# Altium schematic sheet Primary MCU.SchDoc
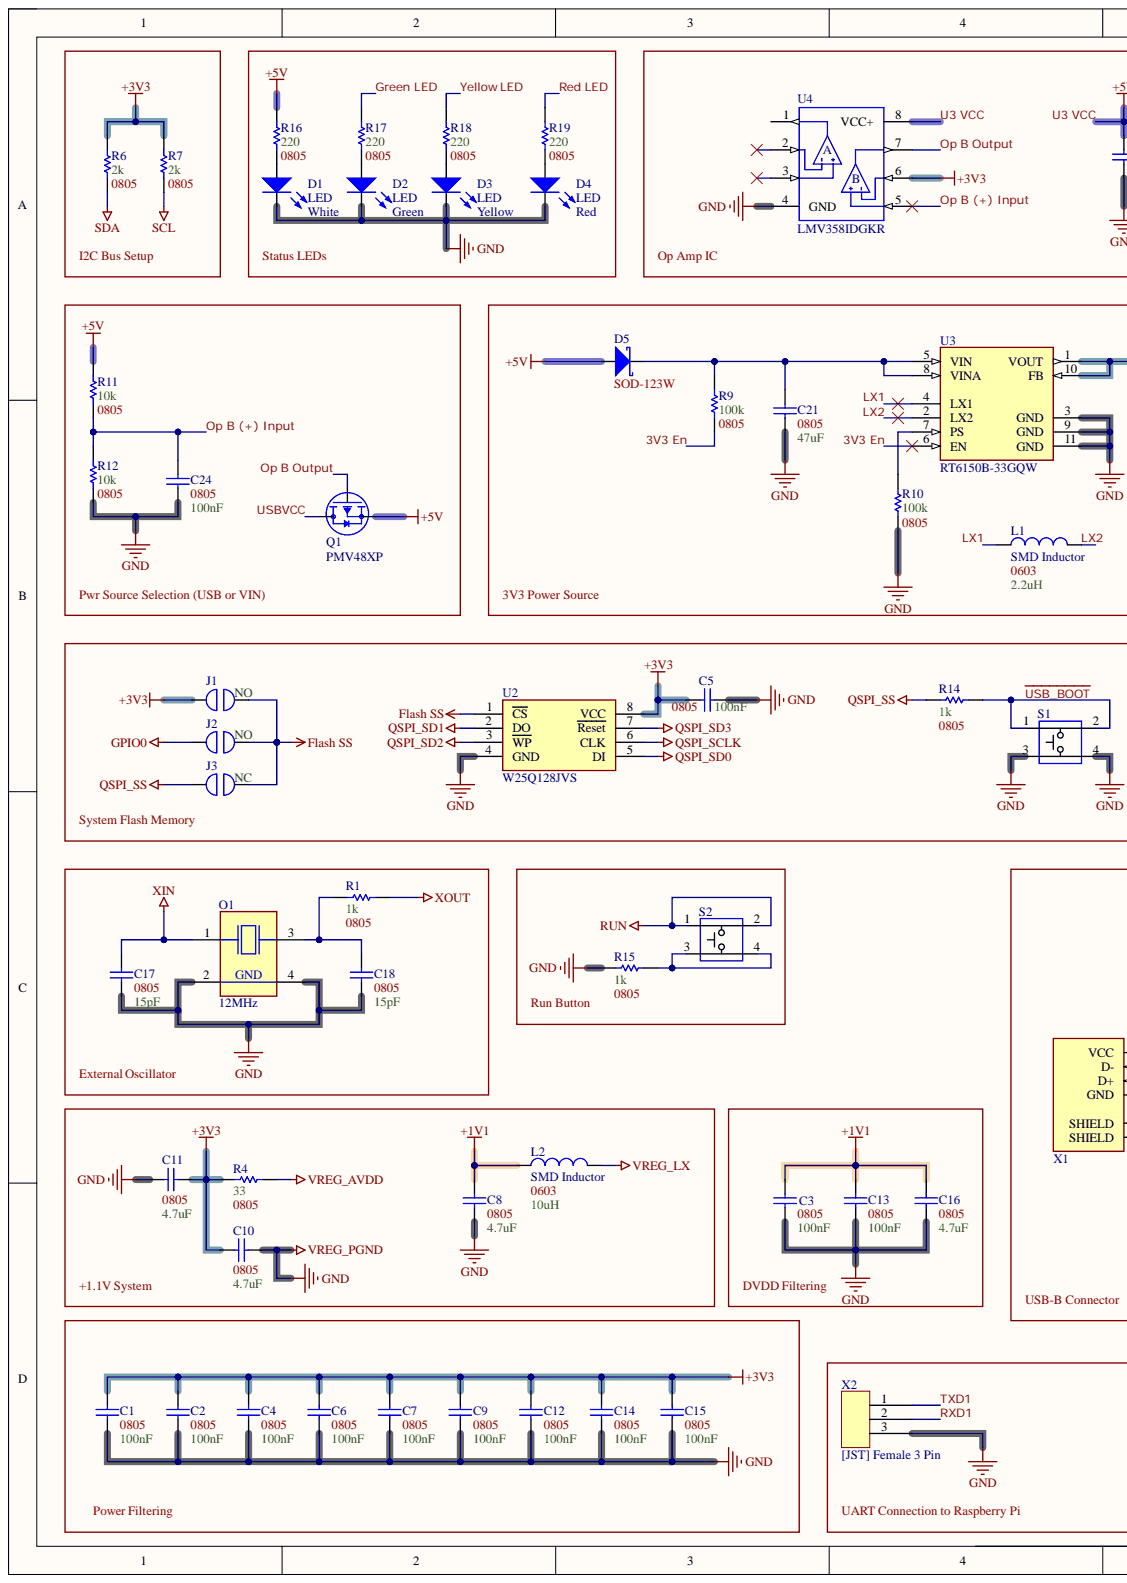
[diagram p0: Primary MCU.SchDoc, left]
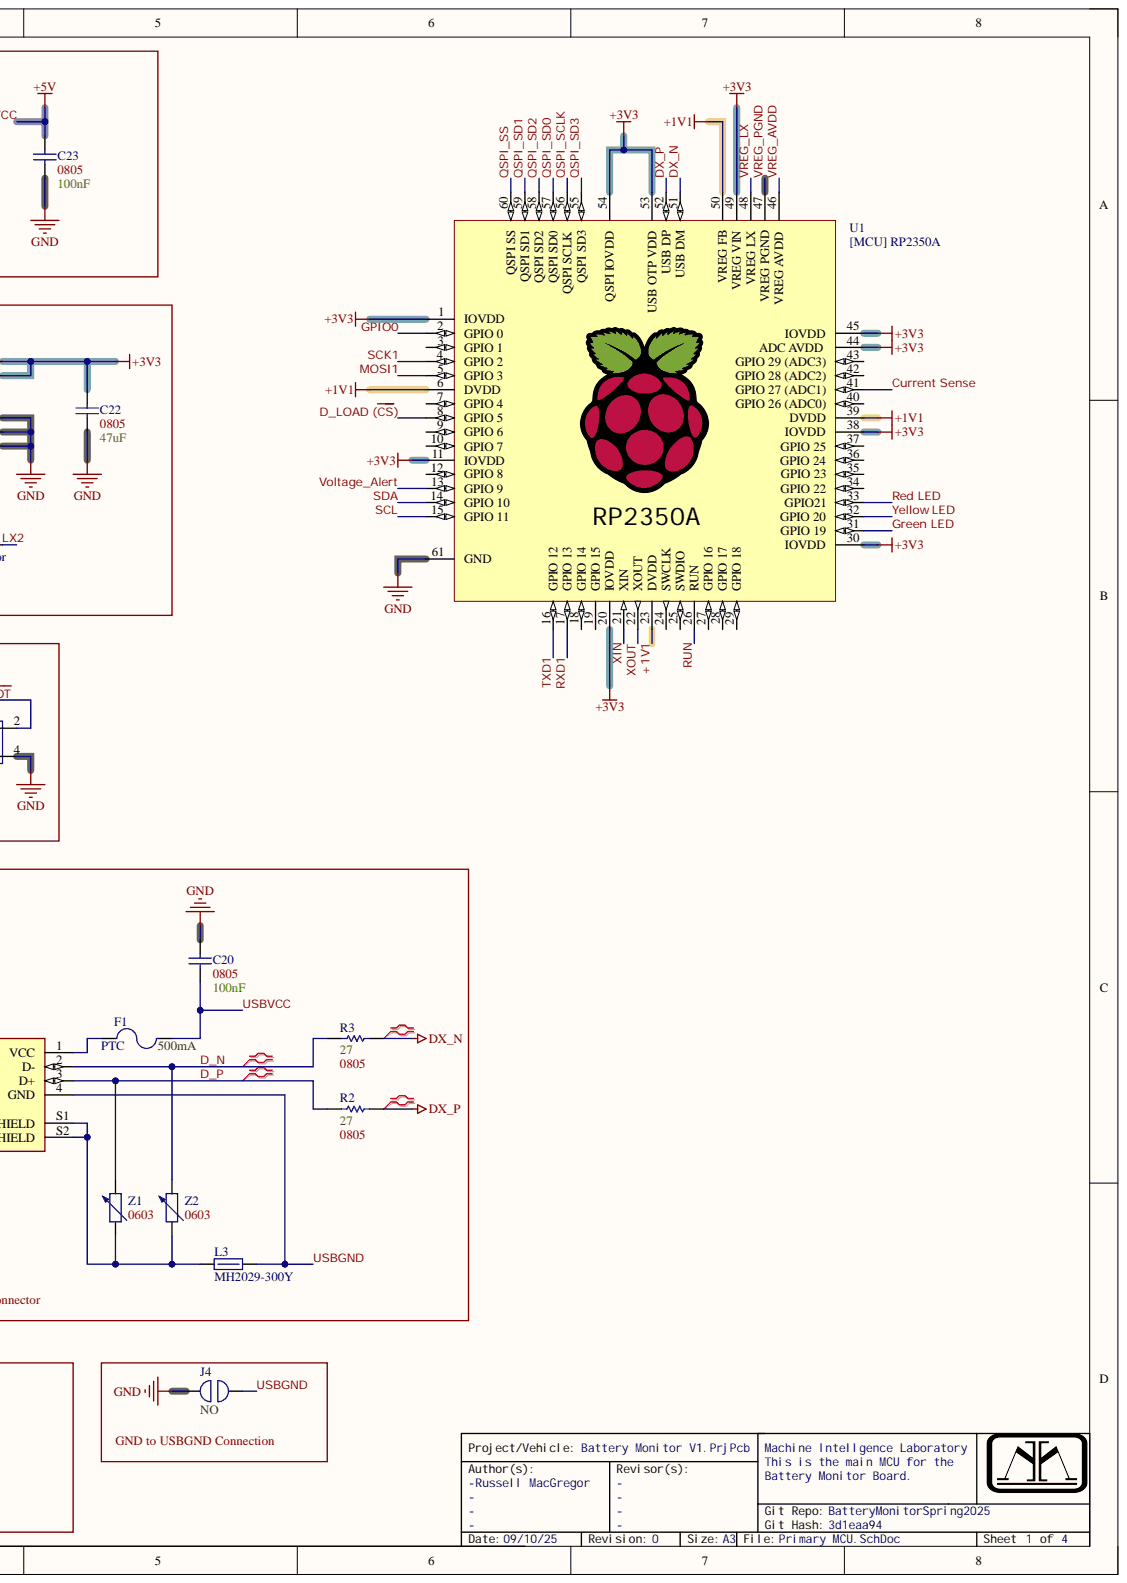
[diagram p1: Primary MCU.SchDoc, right]

## Parts
C1  Capacitance: 100nF  Size Package: 0805  [[Cap] SMD 0805]  (hidden comment: [Cap] SMD Nonpolarized)
C2  Capacitance: 100nF  Size Package: 0805  [[Cap] SMD 0805]  (hidden comment: [Cap] SMD Nonpolarized)
C3  Capacitance: 100nF  Size Package: 0805  [[Cap] SMD 0805]  (hidden comment: [Cap] SMD Nonpolarized)
C4  Capacitance: 100nF  Size Package: 0805  [[Cap] SMD 0805]  (hidden comment: [Cap] SMD Nonpolarized)
C5  Capacitance: 100nF  Size Package: 0805  [[Cap] SMD 0805]  (hidden comment: [Cap] SMD Nonpolarized)
C6  Capacitance: 100nF  Size Package: 0805  [[Cap] SMD 0805]  (hidden comment: [Cap] SMD Nonpolarized)
C7  Capacitance: 100nF  Size Package: 0805  [[Cap] SMD 0805]  (hidden comment: [Cap] SMD Nonpolarized)
C8  Capacitance: 4.7uF  Size Package: 0805  [[Cap] SMD 0805]  (hidden comment: [Cap] SMD Nonpolarized)
C9  Capacitance: 100nF  Size Package: 0805  [[Cap] SMD 0805]  (hidden comment: [Cap] SMD Nonpolarized)
C10  Capacitance: 4.7uF  Size Package: 0805  [[Cap] SMD 0805]  (hidden comment: [Cap] SMD Nonpolarized)
C11  Capacitance: 4.7uF  Size Package: 0805  [[Cap] SMD 0805]  (hidden comment: [Cap] SMD Nonpolarized)
C12  Capacitance: 100nF  Size Package: 0805  [[Cap] SMD 0805]  (hidden comment: [Cap] SMD Nonpolarized)
C13  Capacitance: 100nF  Size Package: 0805  [[Cap] SMD 0805]  (hidden comment: [Cap] SMD Nonpolarized)
C14  Capacitance: 100nF  Size Package: 0805  [[Cap] SMD 0805]  (hidden comment: [Cap] SMD Nonpolarized)
C15  Capacitance: 100nF  Size Package: 0805  [[Cap] SMD 0805]  (hidden comment: [Cap] SMD Nonpolarized)
C16  Capacitance: 4.7uF  Size Package: 0805  [[Cap] SMD 0805]  (hidden comment: [Cap] SMD Nonpolarized)
C17  Capacitance: 15pF  Size Package: 0805  [[Cap] SMD 0805]  (hidden comment: [Cap] SMD Nonpolarized)
C18  Capacitance: 15pF  Size Package: 0805  [[Cap] SMD 0805]  (hidden comment: [Cap] SMD Nonpolarized)
C20  Capacitance: 100nF  Size Package: 0805  [[Cap] SMD 0805]  (hidden comment: [Cap] SMD Nonpolarized)
C21  Capacitance: 47uF  Size Package: 0805  [[Cap] SMD 0805]  (hidden comment: [Cap] SMD Nonpolarized)
C22  Capacitance: 47uF  Size Package: 0805  [[Cap] SMD 0805]  (hidden comment: [Cap] SMD Nonpolarized)
C23  Capacitance: 100nF  Size Package: 0805  [[Cap] SMD 0805]  (hidden comment: [Cap] SMD Nonpolarized)
C24  Capacitance: 100nF  Size Package: 0805  [[Cap] SMD 0805]  (hidden comment: [Cap] SMD Nonpolarized)
D1  LED  Color: White  [[LED] Thru-Hole, 3mm, White]
D2  LED  Color: Green  [[LED] Thru-Hole, 3mm, Green]
D3  LED  Color: Yellow  [[LED] Thru-Hole, 3mm, Yellow]
D4  LED  Color: Red  [[LED] Thru-Hole, 3mm, Red]
D5  Size Package: SOD-123W  [[Diode] SMD SOD-123W]  (hidden comment: Schottky)
F1  PTC  Trip Current: 500mA  [[PTC] 500mA Trip]
J1  NO/NC: NO  [[Jumper] Solderable Jumper]  (hidden comment: [Jump] SMD Jumper)
J2  NO/NC: NO  [[Jumper] Solderable Jumper]  (hidden comment: [Jump] SMD Jumper)
J3  NO/NC: NC  [[Jumper] Solderable Jumper]  (hidden comment: [Jump] SMD Jumper)
J4  NO/NC: NO  [[Jumper] Solderable Jumper]  (hidden comment: [Jump] SMD Jumper)
L1  SMD Inductor  Inductance: 2.2uH  Size Package: 0603  [[Inductor] SMD 0603]
L2  SMD Inductor  Inductance: 10uH  Size Package: 0603  [[Inductor] SMD 0603]
L3  MH2029-300Y  [[Ferrite] SMD Bead 0805 (1)]
O1  12MHz  [[XTAL] SMD 12MHz]
Q1  PMV48XP  [[FET] P-Channel Mosfet]
R1  Resistance: 1k  Size Package: 0805  [[Res] SMD 0805]  (hidden comment: [Res] SMD Resistor)
R2  Size Package: 0805  [[Res] SMD 0805]  (hidden comment: [Res] SMD Resistor)
R3  Size Package: 0805  [[Res] SMD 0805]  (hidden comment: [Res] SMD Resistor)
R4  Size Package: 0805  [[Res] SMD 0805]  (hidden comment: [Res] SMD Resistor)
R6  Resistance: 2k  Size Package: 0805  [[Res] SMD 0805]  (hidden comment: [Res] SMD Resistor)
R7  Resistance: 2k  Size Package: 0805  [[Res] SMD 0805]  (hidden comment: [Res] SMD Resistor)
R9  Resistance: 100k  Size Package: 0805  [[Res] SMD 0805]  (hidden comment: [Res] SMD Resistor)
R10  Resistance: 100k  Size Package: 0805  [[Res] SMD 0805]  (hidden comment: [Res] SMD Resistor)
R11  Resistance: 10k  Size Package: 0805  [[Res] SMD 0805]  (hidden comment: [Res] SMD Resistor)
R12  Resistance: 10k  Size Package: 0805  [[Res] SMD 0805]  (hidden comment: [Res] SMD Resistor)
R14  Resistance: 1k  Size Package: 0805  [[Res] SMD 0805]  (hidden comment: [Res] SMD Resistor)
R15  Resistance: 1k  Size Package: 0805  [[Res] SMD 0805]  (hidden comment: [Res] SMD Resistor)
R16  Size Package: 0805  [[Res] SMD 0805]  (hidden comment: [Res] SMD Resistor)
R17  Size Package: 0805  [[Res] SMD 0805]  (hidden comment: [Res] SMD Resistor)
R18  Size Package: 0805  [[Res] SMD 0805]  (hidden comment: [Res] SMD Resistor)
R19  Size Package: 0805  [[Res] SMD 0805]  (hidden comment: [Res] SMD Resistor)
S1  Standard pushbutton  [[Switch] Pushbutton]  (value not drawn)
S2  Standard pushbutton  [[Switch] Pushbutton]  (value not drawn)
U1  [MCU] RP2350A  [[MCU] RP2350A]
U2  W25Q128JVS  [[IC] 16Mb Flash Memory]
U3  RT6150B-33GQW  [[IC] 3V3 Regulator (2)]
U4  LMV358IDGKR  [[IC] Dual Op-Ap]
X1  [Port] USB Type-B Female (Thru-Hole)  [[Port] USB Type-B Thru-Hole]  (value not drawn)
X2  [JST] Female 3 Pin  [[JST] 3PIN]
Z1  Size Package: 0603  [[Inductor] SMD Varistor 0603]  (hidden comment: [Inductor] SMD Varistor)
Z2  Size Package: 0603  [[Inductor] SMD Varistor 0603]  (hidden comment: [Inductor] SMD Varistor)

## Nets
Flash SS: J1.2 J2.2 J3.2 U2.1(CS)
3V3 En: C1.2 C2.2 C4.2 C5.2 C6.2 C7.2 C9.2 C10.2 C11.1 C12.2 C14.2 C15.2 C22.2 J1.1 R4.2 R6.2 R7.2 R9.1 U1.1(IOVDD) U1.11(IOVDD) U1.20(IOVDD) U1.30(IOVDD) U1.38(IOVDD) U1.44(ADC_AVDD) U1.45(IOVDD) U1.49(VREG_VIN) U1.53(USB_OTP_VDD) U1.54(QSPI_IOVDD) U2.8(VCC) U3.1(VOUT) U3.6(EN) U3.10(FB) U4.6(B)
+1V1: C3.2 C8.2 C13.2 C16.2 L2.1 U1.6(DVDD) U1.23(DVDD) U1.39(DVDD) U1.50(VREG_FB)
Current Sense: U1.41(GPIO_27_(ADC1))
D_LOAD (~{CS}): U1.8(GPIO_5)
D_N: R3.2 X1.2(D-) Z2.2
D_P: R2.2 X1.3(D+) Z1.2
DX_N: R3.1 U1.51(USB_DM)
DX_P: R2.1 U1.52(USB_DP)
GPIO0: J2.1 U1.2(GPIO_0)
Green LED: R17.1 U1.31(GPIO_19)
LX1: L1.1 U3.4(LX1)
LX2: L1.2 U3.2(LX2)
MOSI1: U1.5(GPIO_3)
Op B (+) Input: C24.2 R11.1 R12.2 U4.5
Op B Output: Q1.1 U4.7
QSPI_SCLK: U1.56(QSPI_SCLK) U2.6(CLK)
QSPI_SD0: U1.57(QSPI_SD0) U2.5(DI)
QSPI_SD1: U1.59(QSPI_SD1) U2.2(DO)
QSPI_SD2: U1.58(QSPI_SD2) U2.3(WP)
QSPI_SD3: U1.55(QSPI_SD3) U2.7(Reset)
QSPI_SS: J3.1 R14.2 U1.60(QSPI_SS)
Red LED: R19.1 U1.33(GPIO21)
RUN: S2.1 S2.2 U1.26(RUN)
RXD1: U1.17(GPIO_13) X2.2
SCK1: U1.4(GPIO_2)
SCL: R7.1 U1.15(GPIO_11)
SDA: R6.1 U1.14(GPIO_10)
TXD1: U1.16(GPIO_12) X2.1
U3 VCC: C23.2 D5.2 Q1.2 R11.2 R16.1 U4.8(VCC+)
~{USB_BOOT}: R14.1 S1.1 S1.2
USBGND: J4.2 L3.2 X1.4(GND)
USBVCC: C20.1 F1.2 Q1.3
Voltage_Alert: U1.13(GPIO_9)
VREG_AVDD: R4.1 U1.46(VREG_AVDD)
VREG_LX: L2.2 U1.48(VREG_LX)
VREG_PGND: C1.1 C2.1 C3.1 C4.1 C5.1 C6.1 C7.1 C8.1 C9.1 C10.1 C11.2 C12.1 C13.1 C14.1 C15.1 C16.1 C17.1 C18.1 C20.2 C21.1 C22.1 C23.1 C24.1 D1.2 D2.2 D3.2 D4.2 J4.1 O1.2 O1.4 R10.1 R12.1 R15.2 S1.3 S1.4 U1.47(VREG_PGND) U1.61(GND) U2.4(GND) U3.3(GND) U3.9(GND) U3.11(GND) U4.4(GND) X2.3
XIN: C17.2 O1.1 U1.21(XIN)
XOUT: R1.1 U1.22(XOUT)
Yellow LED: R18.1 U1.32(GPIO_20)
NetC18_2: C18.2 O1.3 R1.2
NetC21_2: C21.2 D5.1 R9.2 U3.5(VIN) U3.8(VINA)
NetD1_1: D1.1 R16.2
NetD2_1: D2.1 R17.2
NetD3_1: D3.1 R18.2
NetD4_1: D4.1 R19.2
NetF1_1: F1.1 X1.1(VCC)
NetL3_1: L3.1 X1.S1(SHIELD) X1.S2(SHIELD) Z1.1 Z2.1
NetR10_2: R10.2 U3.7(PS)
NetR15_1: R15.1 S2.3 S2.4

Not connected: U1 3(GPIO_1) 7(GPIO_4) 9(GPIO_6) 10(GPIO_7) 12(GPIO_8) 18(GPIO_14) 19(GPIO_15) 24(SWCLK) 25(SWDIO) 27(GPIO_16) 28(GPIO_17) 29(GPIO_18) 34(GPIO_22) 35(GPIO_23) 36(GPIO_24) 37(GPIO_25) 40(GPIO_26_(ADC0)) 42(GPIO_28_(ADC2)) 43(GPIO_29_(ADC3)); U4 1 2(A) 3

## Library data of the parts
D1-D4 = [LED] Thru-Hole 3mm: 3mm LED; Component Kind: Standard; Library Name: RADM Components.IntLib
D5 = [Diode] SMD Schottky: PMEG6010ELR; Component Kind: Standard; Library Name: RADM Components.IntLib
F1 = [PTC] 500mA Trip: MF-MSMF050-2  JLC Part #: C17313; Component Kind: Standard; JLC Part #: C17313; Size Package: 1812; Library Name: RADM Components.IntLib
J1-J4 = [Jump] SMD Jumper: Solderable jumper; Component Kind: Standard; Library Name: RADM Components.IntLib
L1 L2 = [Inductor] SMD Inductor: Component Kind: Standard; Library Name: RADM Components.IntLib
L3 = [Ferrite] SMD Ferrite Bead (1): 652-MH2029-300Y; Res @ Freq: 30 @ 100MHz; Component Kind: Standard; Size Package: 0805; Library Name: RADM Components.IntLib
O1 = [XTAL] SMD 12MHz: 815-ABM8-272-T3; Component Kind: Standard; Library Name: RADM Components.IntLib
Q1 = [FET] P-Channel MOSFET: Component Kind: Standard; Description: PMV48XP; JLC Part #: C2959854; Library Name: RADM Components.IntLib
R2 R3 = [Res] SMD Resistor: Resistance: 27; Component Kind: Standard; Library Name: RADM Components.IntLib
R4 = [Res] SMD Resistor: Resistance: 33; Component Kind: Standard; Library Name: RADM Components.IntLib
R16-R19 = [Res] SMD Resistor: Resistance: 220; Component Kind: Standard; Library Name: RADM Components.IntLib
S1 S2 = [Switch] Pushbutton: Component Kind: Standard; Library Name: RADM Components.IntLib
U2 = [IC] 16Mb Flash Memory: W25Q128JVSIQ TR; Component Kind: Standard; Library Name: RADM Components.IntLib
U3 = [IC] 3V3 Regulator (2): Component Kind: Standard; Description: RT6150B-33GQW; Library Name: RADM Components.IntLib
U4 = [IC] Dual Op-Amp: Component Kind: Standard; Description: LMV358IDGKR; JLC Part #: C105242; Library Name: RADM Components.IntLib
X1 = [Port] USB Type-B Female (Thru-Hole): USB-B-S-F-B-TH; Component Kind: Standard; JLC Part #: C5714571; Library Name: RADM Components.IntLib
C1-C18 C20-C24 = [Cap] SMD Nonpolarized: Component Kind: Standard; Library Name: RADM Components.IntLib
R1 R6 R7 R9-R12 R14 R15 = [Res] SMD Resistor: Component Kind: Standard; Library Name: RADM Components.IntLib
U1 = [MCU] RP2350A: Component Kind: Standard; Library Name: RADM Components.IntLib
X2 = [JST] Female 3 Pin: Component Kind: Standard; Library Name: RADM Components.IntLib
Z1 Z2 = [Inductor] SMD Varistor: Component Kind: Standard; JLC Part #: C840634; Library Name: RADM Components.IntLib
